# Altium schematic sheet NUC_power.SchDoc
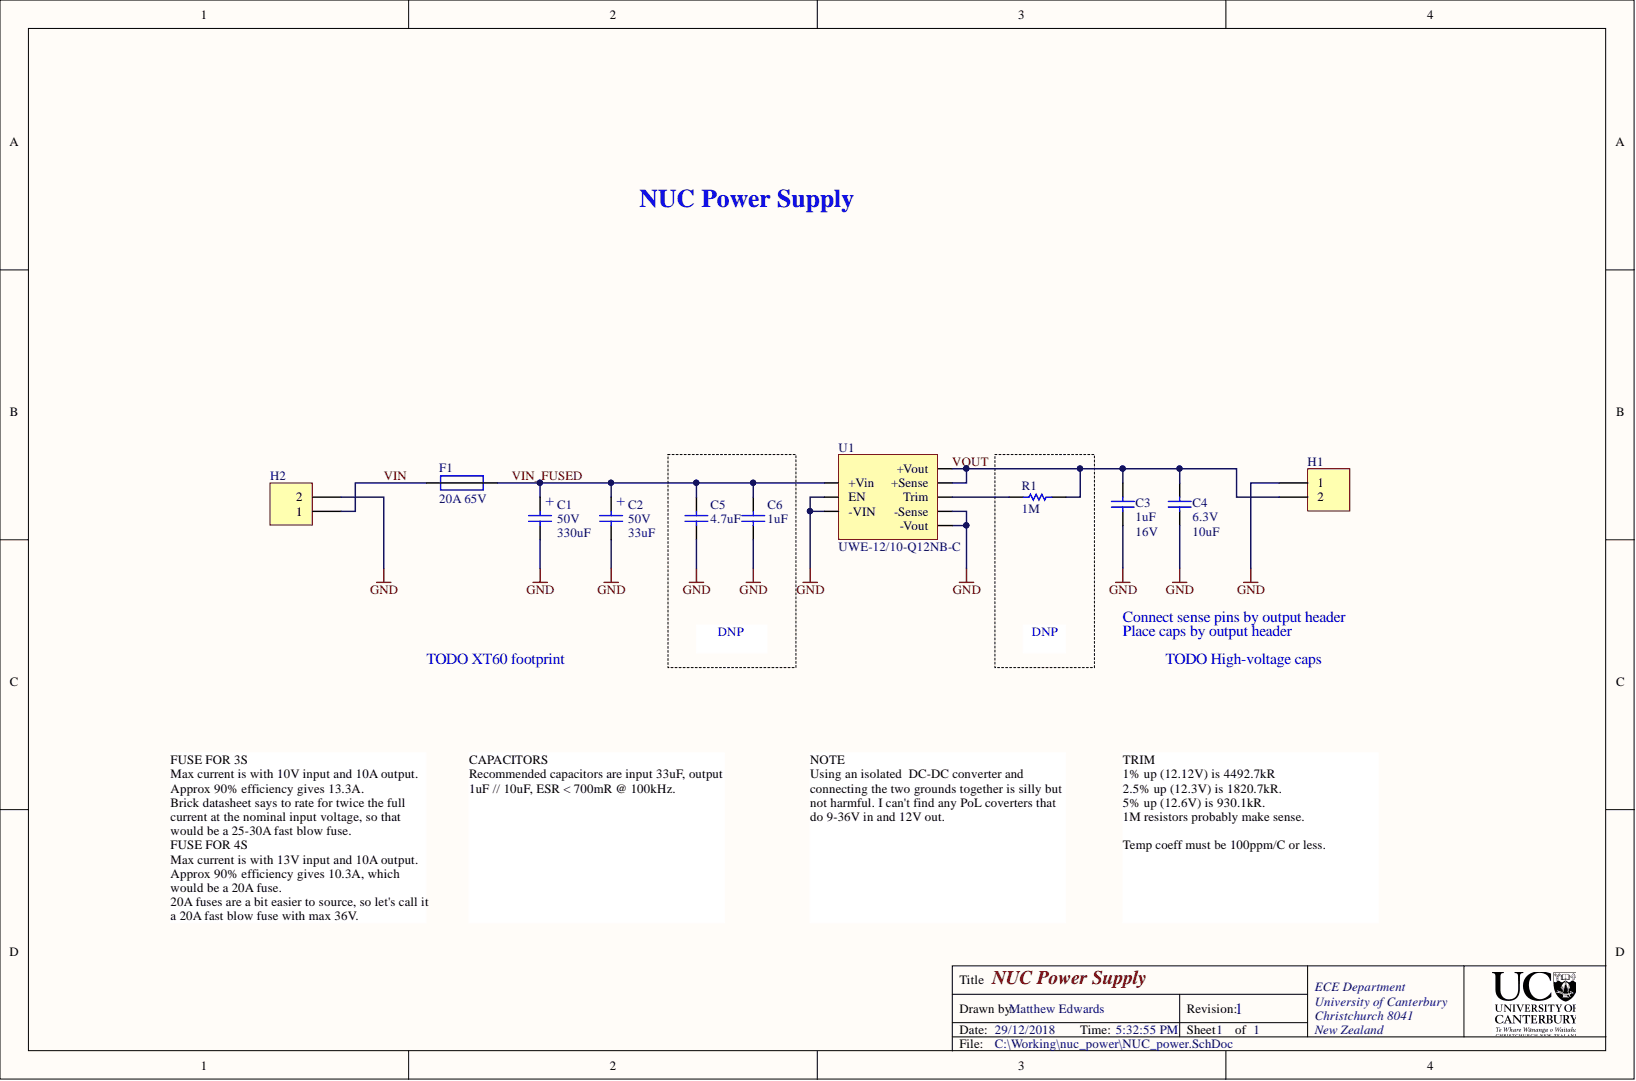
[diagram p0: NUC_power.SchDoc]

## Parts
C1  330uF 50V  value 330uF  Mav Voltage: 50V  [CAP_16x10]
C2  33uF 50V  value 33uF  Mav Voltage: 50V  [CAP_11x5]
C3  1uF  Max Voltage: 16V  [CAPC3216L]
C4  10uF  Voltage - Rated: 6.3V  [CAPC1608L]
C5  4.7uF  [CAPC2012L]
C6  1uF  [CAPC1608L]
F1  20A 65V  [2410_FUSE]
H1  JA_TERM_BLK_HDR_2 PIN  [TMHDR2]  (value not drawn)
H2  JA_TERM_BLK_HDR_2 PIN  [TMHDR2]  (value not drawn)
R1  1M  [RESC1608L]
U1  UWE-12/10-Q12NB-C  [MURATA_UWE-100-120W with 1/16]

## Nets
GND: C1.2 C2.2 C3.1 C4.1 C5.1 C6.1 H1.1 H2.2 U1.2(EN) U1.3(-VIN) U1.4(-Vout) U1.5(-Sense)
VIN: F1.0 H2.1
VIN_FUSED: C1.1 C2.1 C5.2 C6.2 F1.1 U1.1(+Vin)
VOUT: C3.2 C4.2 H1.2 R1.2 U1.7(+Sense) U1.8(+Vout)
NetR1_1: R1.1 U1.6(Trim)

## Library data of the parts
C1 = CAP_TH_330U: Cap TH 330uF 50V; Component Kind: Standard; Library Name: Passives.SchLib
C2 = CAP_TH_33U: Cap TH 33uF 50V; Component Kind: Standard; Library Name: Passives.SchLib
C3 C6 = CC_1uF_0603: CAP CER 1uF Y5V -20% +80% 16V 0603; Code_IPC: 1608 [0603]; Component Kind: Standard; PackageReference: 0603; Signal Integrity: C0603; Simulation: CAP; Storage Location: PCB Assembly WS; Supplier: ECE Dept; Value: 1uF
  C3: Library Name: ECE Components_18V2.IntLib
  C6: Library Name: Passives.SchLib; Max Voltage: 16V
C4 = CC_10uF_0603: CAP CER 10UF X5R +-20% 6V3 0603; Component Kind: Standard; Library Name: ECE Components_18V2.IntLib; Signal Integrity: C0805; Simulation: CAP; Storage Location: PCB Assembly WS; Supplier: ECE Dept; Value: 10uF
C5 = CC_4.7uF_0805: CAP CER 4.7uF Y5V -20% +80% 25V 0805; Note: Footprint corresponds to dimensions for bulk cassette packaging. This case size has tightened tolerances.; Code_IPC: 2012[0805]; Component Kind: Standard; Library Name: Passives.SchLib; Max Voltage: 25V; PackageReference: 0805; Signal Integrity: C0805; Simulation: CAP; Storage Location: PCB Assembly WS; Supplier: ECE Dept; Value: 4.7uF
F1 = FUSE_20A: FUSE FAST BLOW 20A 65V 2410; Manufacturer 1: Bel Fuse Inc.; Manufacturer Part Number 1: 0679L9200-05; Component Kind: Standard; Library Name: Passives.SchLib; Manufacturer 2: Littelfuse Inc.; Manufacturer Part Number 2: 0451020.MRL; Supplier 1: Digi-Key; Supplier 2: Digi-Key; Supplier Part Number 1: 507-2001-1-ND; Supplier Part Number 2: F8251CT-ND
H1 H2 = JA_TERM_BLK_HDR_2 PIN: TERM_BLK_HEADER 5.08mm 2 Pos R/A Thru Hole; Manufacturer: Phoenix Contact; Manufacturer Part Number: 1727010; Max Current Rating: 12A; Max Supply Voltage: 160V; Component Kind: Standard; Library Name: ECE Components_18V2.IntLib; Signal Integrity: Connector; Storage Location: ECE Dept Store; Supplier: ECE Dept; Supplier 1: Farnell; Supplier 2: Digi-Key; Supplier Part Number 1: 3704579; Supplier Part Number 2: 277-1947-ND
R1 = RS_1M: RES 1.0M OHM 1/10W 5% 0603 SMD; Component Kind: Standard; Library Name: Passives.SchLib; Signal Integrity: Res; Simulation: RES; Storage Location: PCB Assembly WS; Supplier: ECE Dept; Value: 1M
U1 = UWE-12/10-Q12NB-C: Isolated Module DC DC Converter 1 Output 12V 10A 9V - 36V Input; Manufacturer: Murata Power Solutions Inc.; Component Kind: Standard; ComponentLink1Description: https://power.murata.com/data/power/uwe-100-120.pdf; ComponentLink1URL: https://power.murata.com/data/power/uwe-100-120.pdf; Current - Output (Max): 10A; Manufacturer Part Number: UWE-12/10-Q12NB-C; Supplier 1: Digi-Key; Supplier Part Number 1: UWE-12/10-Q12NB-C-ND; Type: Isolated Module; Voltage - Input (Max): 36V; Voltage - Input (Min): 9V; Library Name: DOSA_bricks.SchLib
